# Altium schematic sheet Seven-Seg Displays.SchDoc
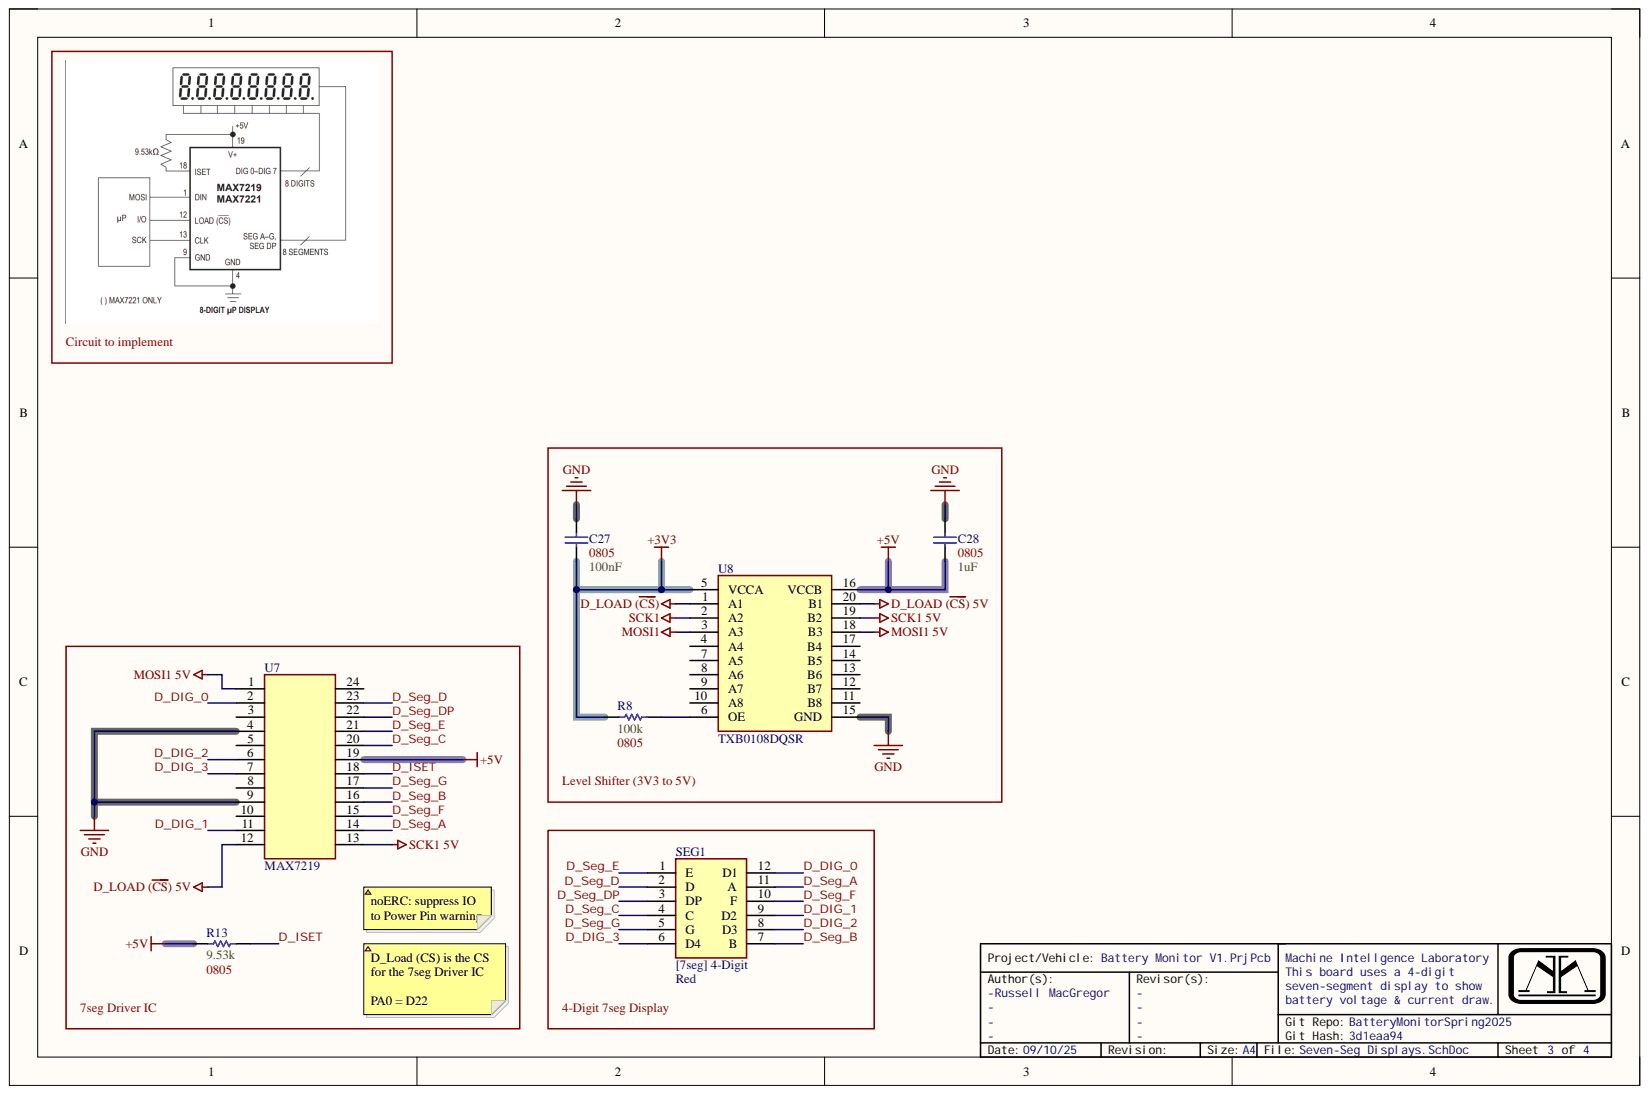
[diagram p0: Seven-Seg Displays.SchDoc]

## Parts
C27  Capacitance: 100nF  Size Package: 0805  [[Cap] SMD 0805]  (hidden comment: [Cap] SMD Nonpolarized)
C28  Capacitance: 1uF  Size Package: 0805  [[Cap] SMD 0805]  (hidden comment: [Cap] SMD Nonpolarized)
R8  Resistance: 100k  Size Package: 0805  [[Res] SMD 0805]  (hidden comment: [Res] SMD Resistor)
R13  Resistance: 9.53k  Size Package: 0805  [[Res] SMD 0805]  (hidden comment: [Res] SMD Resistor)
SEG1  [7seg] 4-Digit  Color: Red  [[7seg] 4-Digit]
U7  MAX7219  [[DIP IC] 24pin, Socket]
U8  TXB0108DQSR  [[IC] Level Shifter]

## Nets
+3V3: C27.1 R8.1 U8.5(VCCA)
+5V: C28.1 R13.1 U7.19 U8.16(VCCB)
D_LOAD (~{CS}): U8.1(A1)
D_LOAD (~{CS}) 5V: U7.12 U8.20(B1)
GND: C27.2 C28.2 U7.4 U7.9 U8.15(GND)
MOSI1: U8.3(A3)
MOSI1 5V: U7.1 U8.18(B3)
SCK1: U8.2(A2)
SCK1 5V: U7.13 U8.19(B2)
D_DIG_0: SEG1.12(D1) U7.2
D_DIG_1: SEG1.9(D2) U7.11
D_DIG_2: SEG1.8(D3) U7.6
D_DIG_3: SEG1.6(D4) U7.7
D_ISET: R13.2 U7.18
D_Seg_A: SEG1.11(A) U7.14
D_Seg_B: SEG1.7(B) U7.16
D_Seg_C: SEG1.4(C) U7.20
D_Seg_D: SEG1.2(D) U7.23
D_Seg_DP: SEG1.3(DP) U7.22
D_Seg_E: SEG1.1(E) U7.21
D_Seg_F: SEG1.10(F) U7.15
D_Seg_G: SEG1.5(G) U7.17
NetR8_2: R8.2 U8.6(OE)

Not connected: U7 3 5 8 10 24; U8 4(A4) 7(A5) 8(A6) 9(A7) 10(A8) 11(B8) 12(B7) 13(B6) 14(B5) 17(B4)

## Library data of the parts
U7 = [DIP IC] 24pin: Component Kind: Standard; Library Name: RADM Components.IntLib
U8 = [IC] Level Shifter: Component Kind: Standard; Description: TXB0108DQSR; Library Name: RADM Components.IntLib
C27 C28 = [Cap] SMD Nonpolarized: Component Kind: Standard; Library Name: RADM Components.IntLib
R8 R13 = [Res] SMD Resistor: Component Kind: Standard; Library Name: RADM Components.IntLib
SEG1 = [7seg] 4-Digit: Component Kind: Standard; Mouser Part #: 474-COM-11405; Library Name: RADM Components.IntLib
